# Altium schematic sheet LAMP.SchDoc
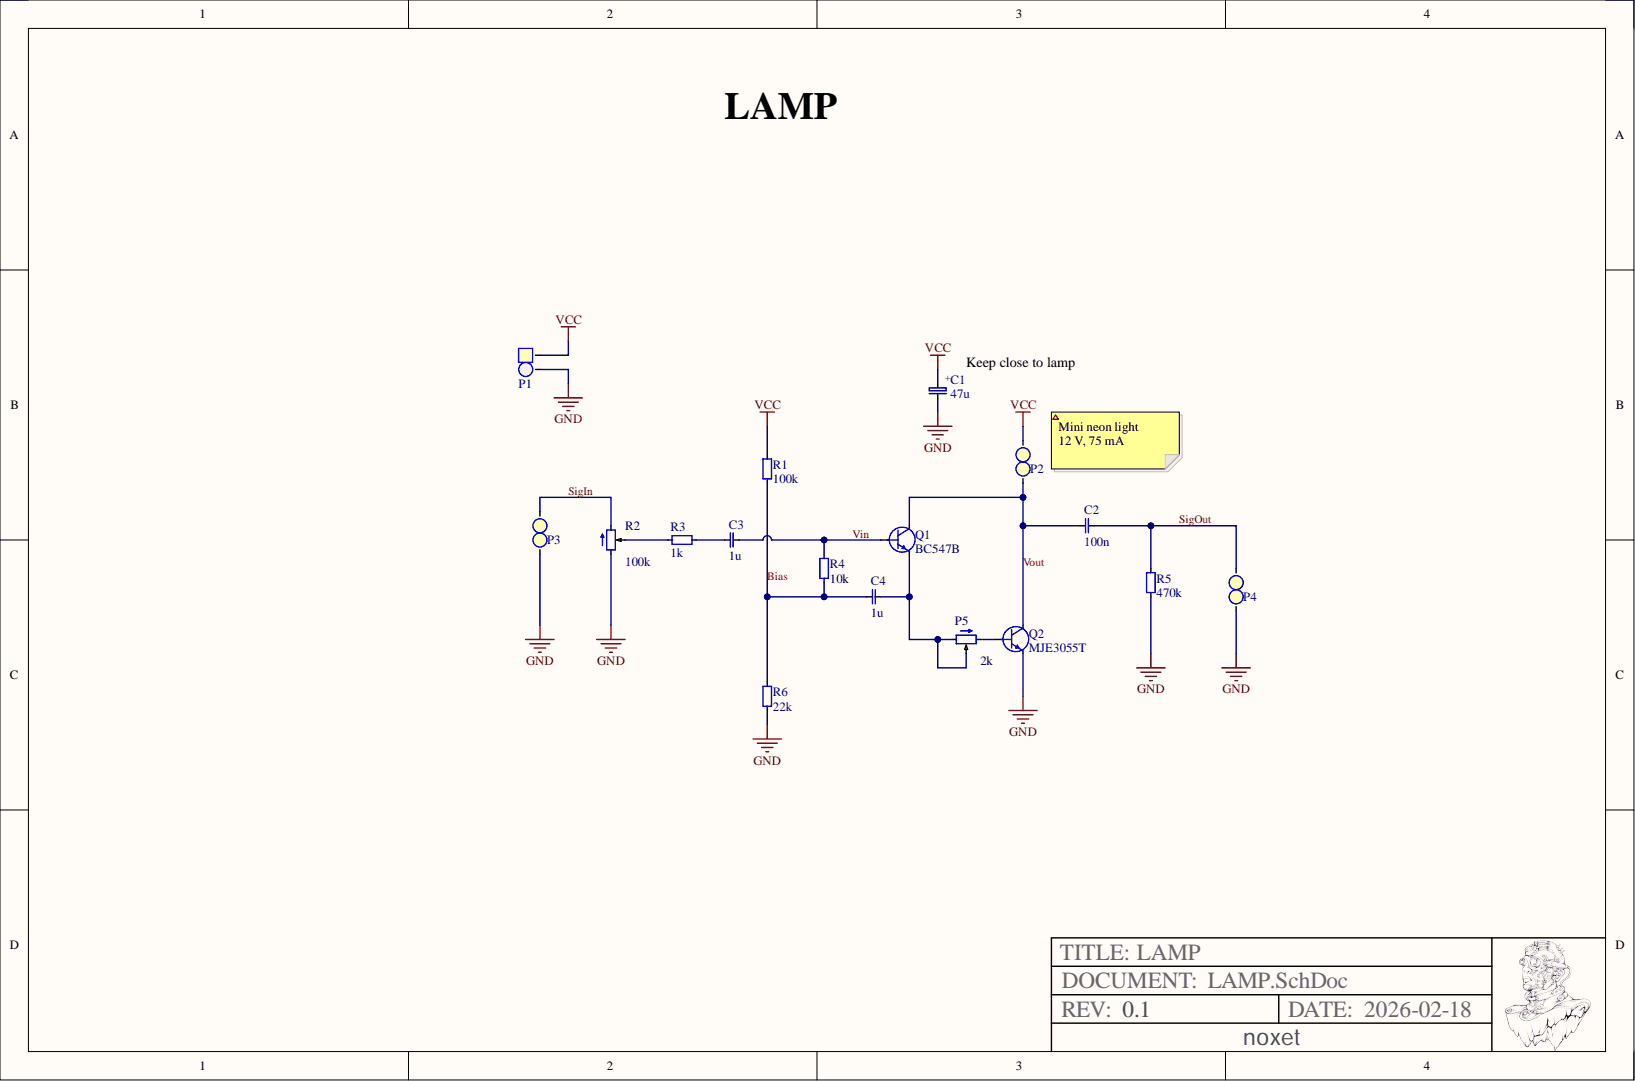
[diagram p0: LAMP.SchDoc]

## Parts
C1  value 47u  [Cap, SMD, Polar, 5.3x5.3mm]  (hidden comment: Capacitor, TH 2mm)
C2  value 100n  [0805, cap]  (hidden comment: 0805, capacitor)
C3  value 1u  [0805, cap]  (hidden comment: 0805, capacitor)
C4  value 1u  [0805, cap]  (hidden comment: 0805, capacitor)
P1  1x2 Pin Header  [padtwo_L]  (value not drawn)
P2  1x2 Pin Heade Straight  [padtwo_L]  (value not drawn)
P3  1x2 Pin Heade Straight  [padtwo_L]  (value not drawn)
P4  1x2 Pin Heade Straight  [padtwo_L]  (value not drawn)
P5  value 2k  [Pot_3362P]  (hidden comment: Pot)
Q1  BC547B  [BC547_TO-92_BENT]
Q2  MJE3055T  [TO-220AB Angle]
R1  value 100k  [0805]  (hidden comment: General resistor)
R2  100k  [RK09L_15mm]
R3  value 1k  [0805]  (hidden comment: General resistor)
R4  value 10k  [0805]  (hidden comment: General resistor)
R5  value 470k  [0805]  (hidden comment: General resistor)
R6  value 22k  [0805]  (hidden comment: General resistor)

## Nets
GND: C1.1 P1.2 P3.2 P4.2 Q2.3 R2.1 R5.0 R6.1
VCC: C1.2 P1.1 P2.1 R1.0
Bias: C4.2 R1.1 R4.1 R6.0
SigIn: P3.1 R2.3
SigOut: C2.1 P4.1 R5.1
Vin: C3.1 Q1.2 R4.0
Vout: C2.2 P2.2 Q1.1 Q2.2
NetC3_2: C3.2 R3.1
NetC4_1: C4.1 P5.1 P5.2 Q1.3
NetP5_3: P5.3 Q2.1
NetR2_2: R2.2 R3.0

## Library data of the parts
C1 = Capacitor, TH 2mm: Capacitor TH 2mm; Component Kind: Standard; Library Name: jclib.IntLib
P1 = 1x2 Pin Header: 2.54 mm, TH; Component Kind: Standard; Value: 1x2 Pin Header; Library Name: jclib.IntLib
P2-P4 = 1x2 Pin Heade Straight: 2.54 mm, TH; Value: 1x2 Pin Header; Component Kind: Standard; Library Name: jclib.IntLib
Q1 = NPN CBE: Component Kind: Standard; Library Name: jclib.IntLib
Q2 = NPN BCE: Component Kind: Standard; Library Name: jclib.SchLib
R1 R3-R6 = resistor: Component Kind: Standard; Library Name: jclib.IntLib
R2 = Potentiometer: Value: 0; Component Kind: Standard; Description: Potentiometer; ELFA: 300-08-353; Library Name: jclib.IntLib
C2-C4 = 0805, capacitor: Component Kind: Standard; Library Name: jclib.IntLib
P5 = Pot: Component Kind: Standard; Library Name: jclib.IntLib
